# Altium schematic sheet VGA.SchDoc(VGA)
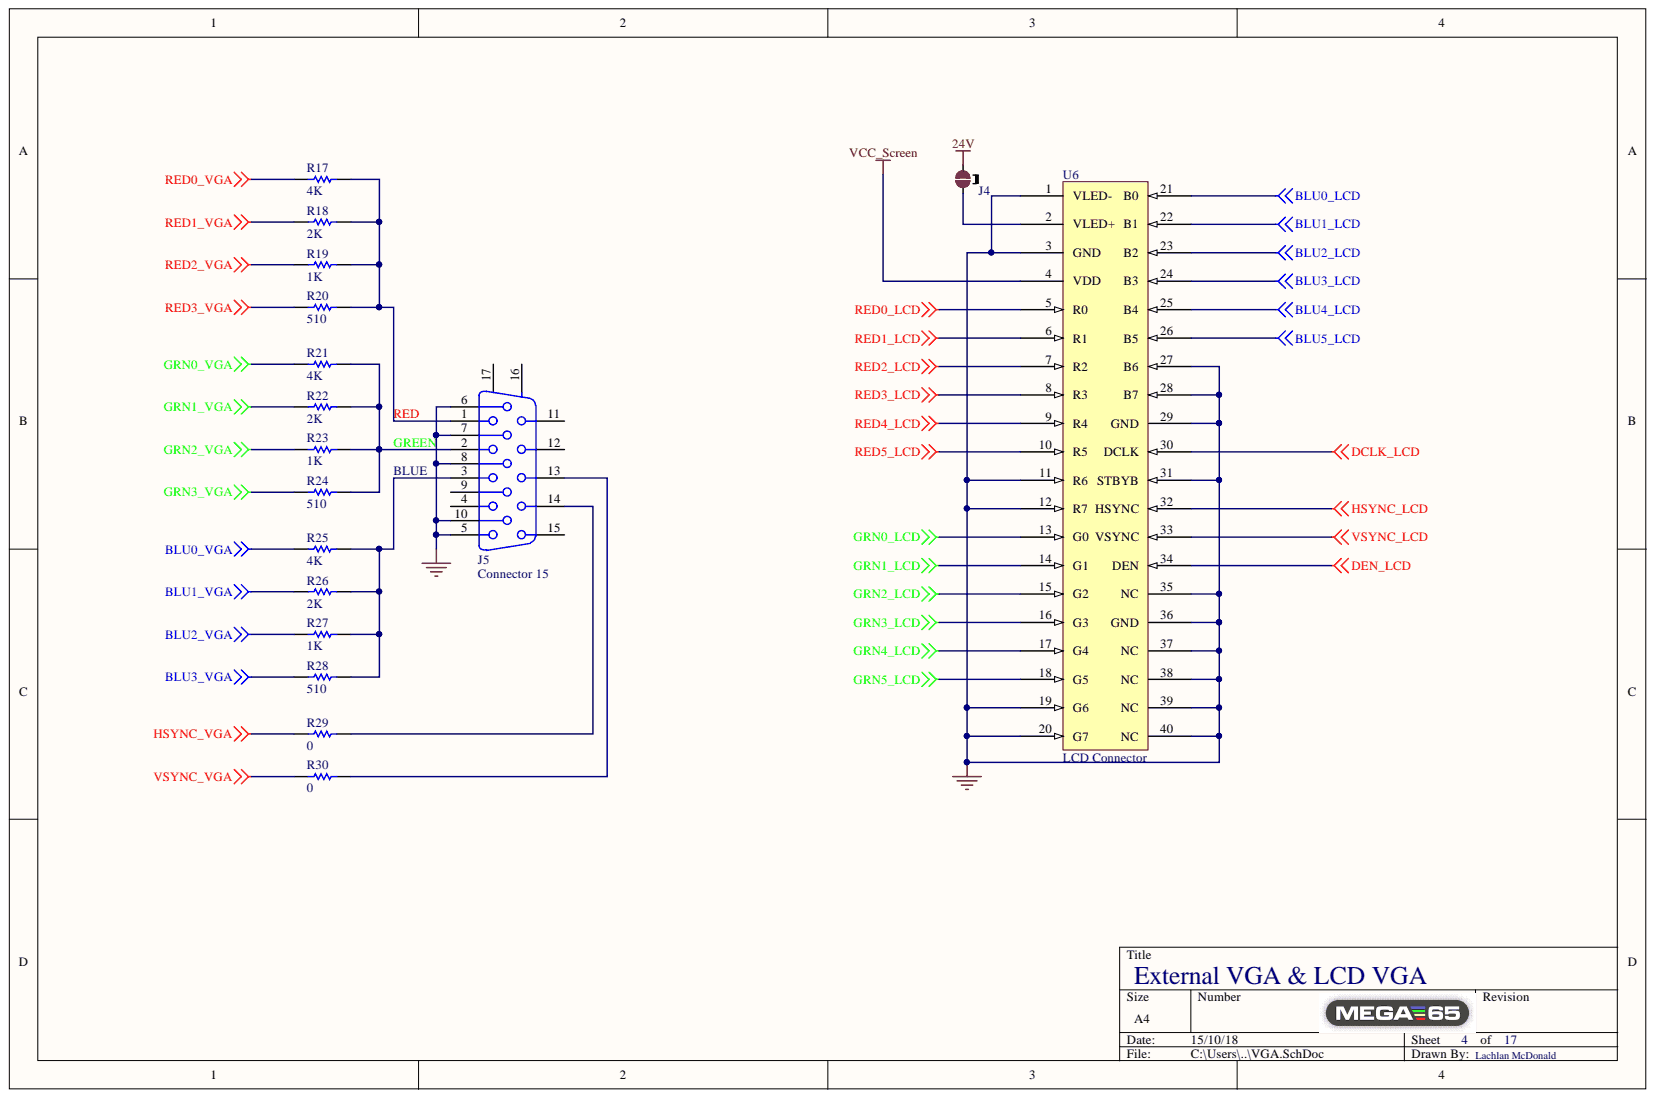
[diagram p0: VGA.SchDoc]

## Parts
J4  2 way net solder junction - Normally Connected  [2waySolder_NC]  (value not drawn)  (no BOM)
J5  Connector 15  [15pinDSUB Connector]
R17  4K  [RESC0805(2012)_M]
R18  2K  [RESC0805(2012)_M]
R19  1K  [RESC0805(2012)_M]
R20  510  [RESC0805(2012)_M]
R21  4K  [RESC0805(2012)_M]
R22  2K  [RESC0805(2012)_M]
R23  1K  [RESC0805(2012)_M]
R24  510  [RESC0805(2012)_M]
R25  4K  [RESC0805(2012)_M]
R26  2K  [RESC0805(2012)_M]
R27  1K  [RESC0805(2012)_M]
R28  510  [RESC0805(2012)_M]
R29  0  [RESC0805(2012)_M]
R30  0  [RESC0805(2012)_M]
U6  LCD Connector  [J_SMD_4-1734839-0-40_0.5_25.43_5.1_2.05_4-1734839-0(Primary)]

## Nets
24V: J4.2
BLU0_LCD: U6.21(B0)
BLU0_VGA: R25.2
BLU1_LCD: U6.22(B1)
BLU1_VGA: R26.2
BLU2_LCD: U6.23(B2)
BLU2_VGA: R27.2
BLU3_LCD: U6.24(B3)
BLU3_VGA: R28.2
BLU4_LCD: U6.25(B4)
BLU5_LCD: U6.26(B5)
DCLK_LCD: U6.30(DCLK)
DEN_LCD: U6.34(DEN)
GND: J5.5 J5.6 J5.7 J5.8 J5.10 U6.1(VLED-) U6.3(GND) U6.11(R6) U6.12(R7) U6.19(G6) U6.20(G7) U6.27(B6) U6.28(B7) U6.29(GND) U6.31(STBYB) U6.35(NC) U6.36(GND) U6.37(NC) U6.38(NC) U6.39(NC) U6.40(NC)
GRN0_LCD: U6.13(G0)
GRN0_VGA: R21.2
GRN1_LCD: U6.14(G1)
GRN1_VGA: R22.2
GRN2_LCD: U6.15(G2)
GRN2_VGA: R23.2
GRN3_LCD: U6.16(G3)
GRN3_VGA: R24.2
GRN4_LCD: U6.17(G4)
GRN5_LCD: U6.18(G5)
HSYNC_LCD: U6.32(HSYNC)
HSYNC_VGA: R29.2
RED0_LCD: U6.5(R0)
RED0_VGA: R17.2
RED1_LCD: U6.6(R1)
RED1_VGA: R18.2
RED2_LCD: U6.7(R2)
RED2_VGA: R19.2
RED3_LCD: U6.8(R3)
RED3_VGA: R20.2
RED4_LCD: U6.9(R4)
RED5_LCD: U6.10(R5)
VCC_Screen: U6.4(VDD)
VSYNC_LCD: U6.33(VSYNC)
VSYNC_VGA: R30.2
NetJ4_1: J4.1 U6.2(VLED+)
NetJ5_1: J5.1 R17.1 R18.1 R19.1 R20.1
NetJ5_2: J5.2 R21.1 R22.1 R23.1 R24.1
NetJ5_3: J5.3 R25.1 R26.1 R27.1 R28.1
NetJ5_13: J5.13 R30.1
NetJ5_14: J5.14 R29.1

Not connected: J5 4 9 11 12 15 16 17

## Library data of the parts
J4 = 2waySolderNC: Library Name: NetTieLib.IntLib; Description: 2 way net solder junction - Normally Connected; ComponentLink1URL: http://www.edatools.cz/addons; ComponentLink1Description: Source
J5 = Connector 15: Receptacle Assembly, 15-Pin, Sim Line Connector; Positions: 15; PackageDocument: B; Gender: Receptacle Header; Signal Integrity: Connector; Published: 15-Jun-2001; PackageReference: 788574; Orientation: Right Angle; Library Name: Miscellaneous Connectors.IntLib; LatestRevisionNote: Re-released for DXP Platform.; LatestRevisionDate: 17-Jul-2002; DatasheetDocument: 24-Nov-1999; Component Kind: Standard; Publisher: Altium Limited
R17-R30 = CMP-1013-00623-1: 10K 0.125W 1% 0805 (2012 Metric)  SMD; Value: 10000.00 Ohm; Case-Metric: 2012; Case-EIA: 0805; Tolerance: 1 %; Technology: SMT; Power: 0.125 W; Library Name: Altium Content Vault; Component Kind: Standard
U6 = LCD Connector: Library Name: Schlib1.SchLib; Component Kind: Standard
